# Altium schematic sheet barGraph.SchDoc
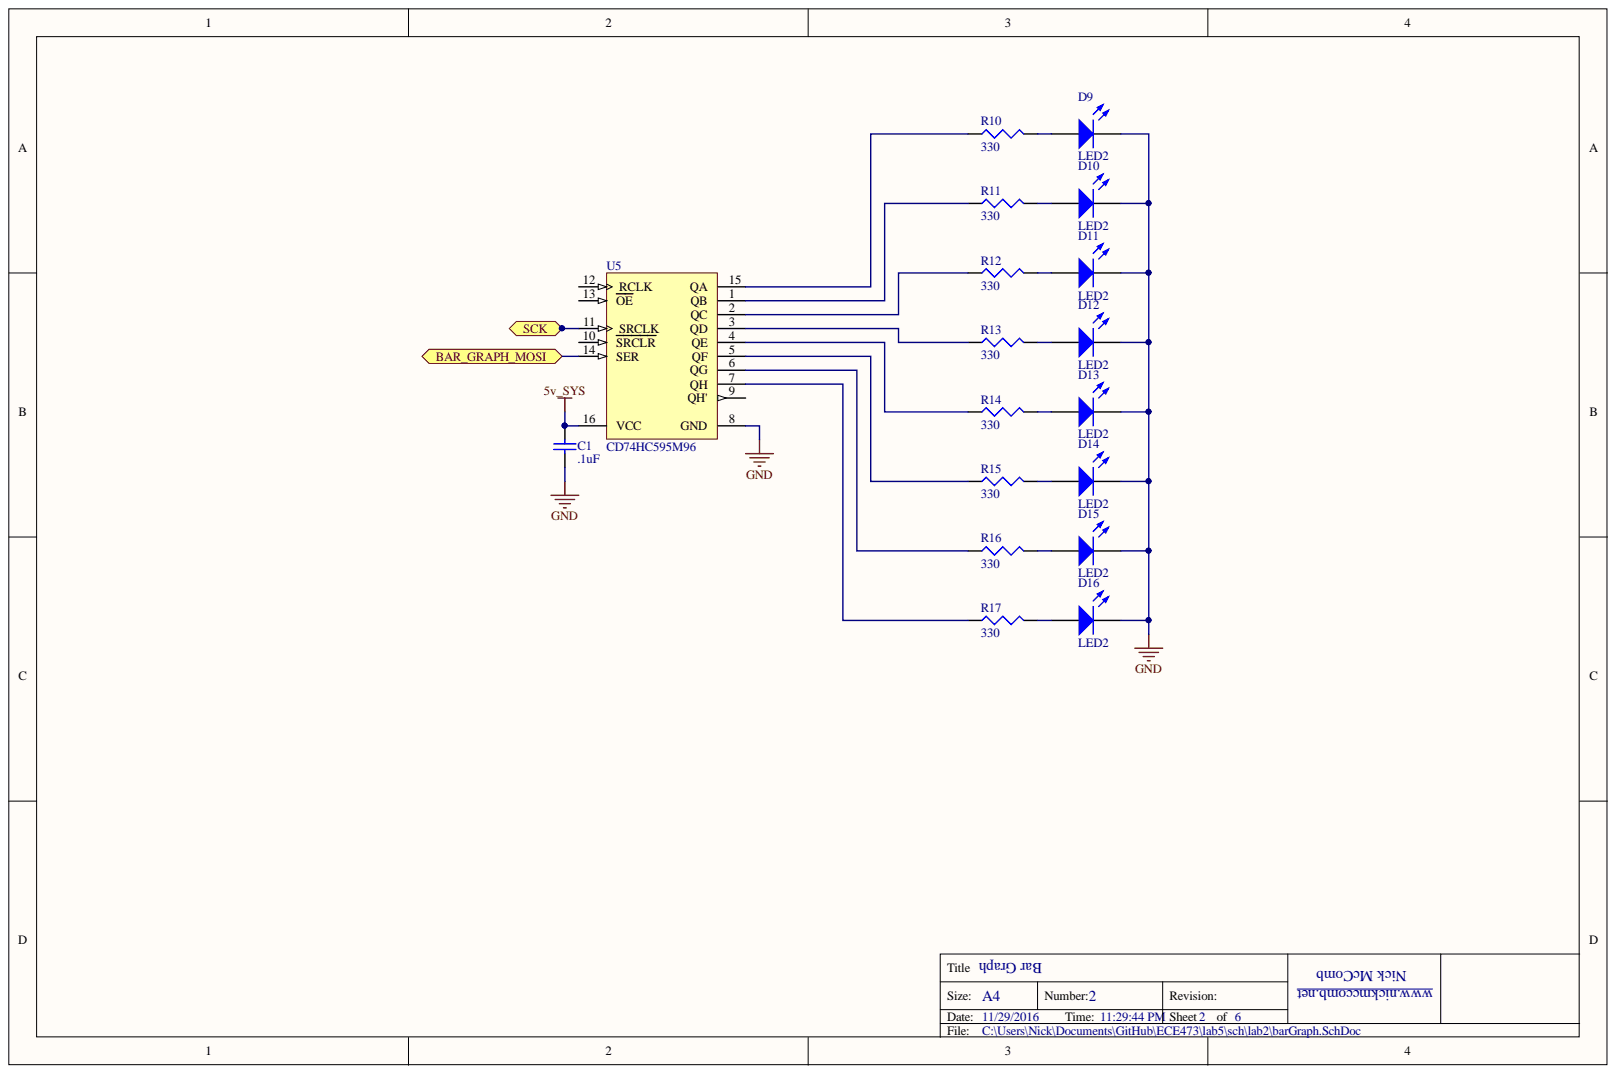
[diagram p0: barGraph.SchDoc]

## Parts
C1  value .1uF  [C0805]  (hidden comment: 0805 style, by default)
D9  LED2  [basic_led]
D10  LED2  [basic_led]
D11  LED2  [basic_led]
D12  LED2  [basic_led]
D13  LED2  [basic_led]
D14  LED2  [basic_led]
D15  LED2  [basic_led]
D16  LED2  [basic_led]
R10  330  [J1-0603]
R11  330  [J1-0603]
R12  330  [J1-0603]
R13  330  [J1-0603]
R14  330  [J1-0603]
R15  330  [J1-0603]
R16  330  [J1-0603]
R17  330  [J1-0603]
U5  CD74HC595M96  [D0016A_L]

## Ports
BAR_GRAPH_MOSI, SCK

## Nets
5v_SYS: C1.2 U5.16(VCC)
GND: C1.1 D9.2 D10.2 D11.2 D12.2 D13.2 D14.2 D15.2 D16.2 U5.8(GND)
BAR_GRAPH_MOSI: U5.14(SER) ; port BAR_GRAPH_MOSI
SCK: U5.11(SRCLK) ; port SCK
NetD9_1: D9.1 R10.2
NetD10_1: D10.1 R11.2
NetD11_1: D11.1 R12.2
NetD12_1: D12.1 R13.2
NetD13_1: D13.1 R14.2
NetD14_1: D14.1 R15.2
NetD15_1: D15.1 R16.2
NetD16_1: D16.1 R17.2
NetR10_1: R10.1 U5.15(QA)
NetR11_1: R11.1 U5.1(QB)
NetR12_1: R12.1 U5.2(QC)
NetR13_1: R13.1 U5.3(QD)
NetR14_1: R14.1 U5.4(QE)
NetR15_1: R15.1 U5.5(QF)
NetR16_1: R16.1 U5.6(QG)
NetR17_1: R17.1 U5.7(QH)

Not connected: U5 9(QH') 10(SRCLR) 12(RCLK) 13(OE)

## Library data of the parts
C1 = Cap: Capacitor; Component Kind: Standard; LatestRevisionDate: 17-Jul-2002; LatestRevisionNote: Re-released for DXP Platform.; Library Name: lab2.SchLib; PackageDescription: Radial Cap, Thru-Hole; 2 Leads; 0.3 in Pin Spacing; PackageReference: RAD-0.3; Published: 8-Jun-2000; Signal Integrity: Cap; Simulation: CAP; Publisher: Altium Limited
D9-D16 = LED2: Typical RED, GREEN, YELLOW, AMBER GaAs LED; Component Kind: Standard; LatestRevisionDate: 17-Jul-2002; LatestRevisionNote: Re-released for DXP Platform.; Library Name: lab2.SchLib; PackageDescription: SM LED; 2 Flat Leads; PackageReference: 3.2X1.6X1.1; PackageVersion: Feb-2002; Published: 8-Jun-2000; Publisher: Altium Limited
R10-R17 = Res3: Resistor; Component Kind: Standard; LatestRevisionDate: 29-May-2009; LatestRevisionNote: IPC-7351 Footprint Added.; Library Name: lab2.SchLib; PackageDescription: Chip Resistor; PackageReference: J1-0603; Published: 8-Jun-2000; Value: 330; Publisher: Altium Limited
U5 = CMP-0807-00025-1: 8-Bit Shift Registers With 3-State Output Registers, D0016A, LARGE T&R; 3-State Output: Yes; Bits: 8; F @ Nom Voltage(Max)(Mhz): 28; ICC @ Nom Voltage(Max)(mA): 0.08; Input Type: CMOS; No. of Outputs: 9; Output Drive (IOL/IOH)(Max)(mA): 7.8/-7.8; Rating: Catalog; Technology Family: HC; tpd @ Nom Voltage(Max)(ns): 41; VCC(Max)(V): 6; VCC(Min)(V): 2; Code_IPC: MS-012 variation AC; Component Kind: Standard; ComponentLink1Description: Manufacturer URL; ComponentLink1URL: http://www.ti.com/; ComponentLink2Description: Datasheet; ComponentLink2URL: http://www.ti.com/lit/ds/symlink/cd74hc595.pdf; DatasheetVersion: SCHS353; Library Name: Altium Content Vault; Operating Temperature Range(C): -55 to 125; Output Type: CMOS; PackageReference: D0016A; PackageVersion: http://www.ti.com/lit/ml/mpds178g/mpds178g.pdf; Part Number: CD74HC595; PartNumber: CD74HC595M96; Voltage(Nom)(V): 6
